# Altium schematic sheet PMU.SchDoc(PMU)
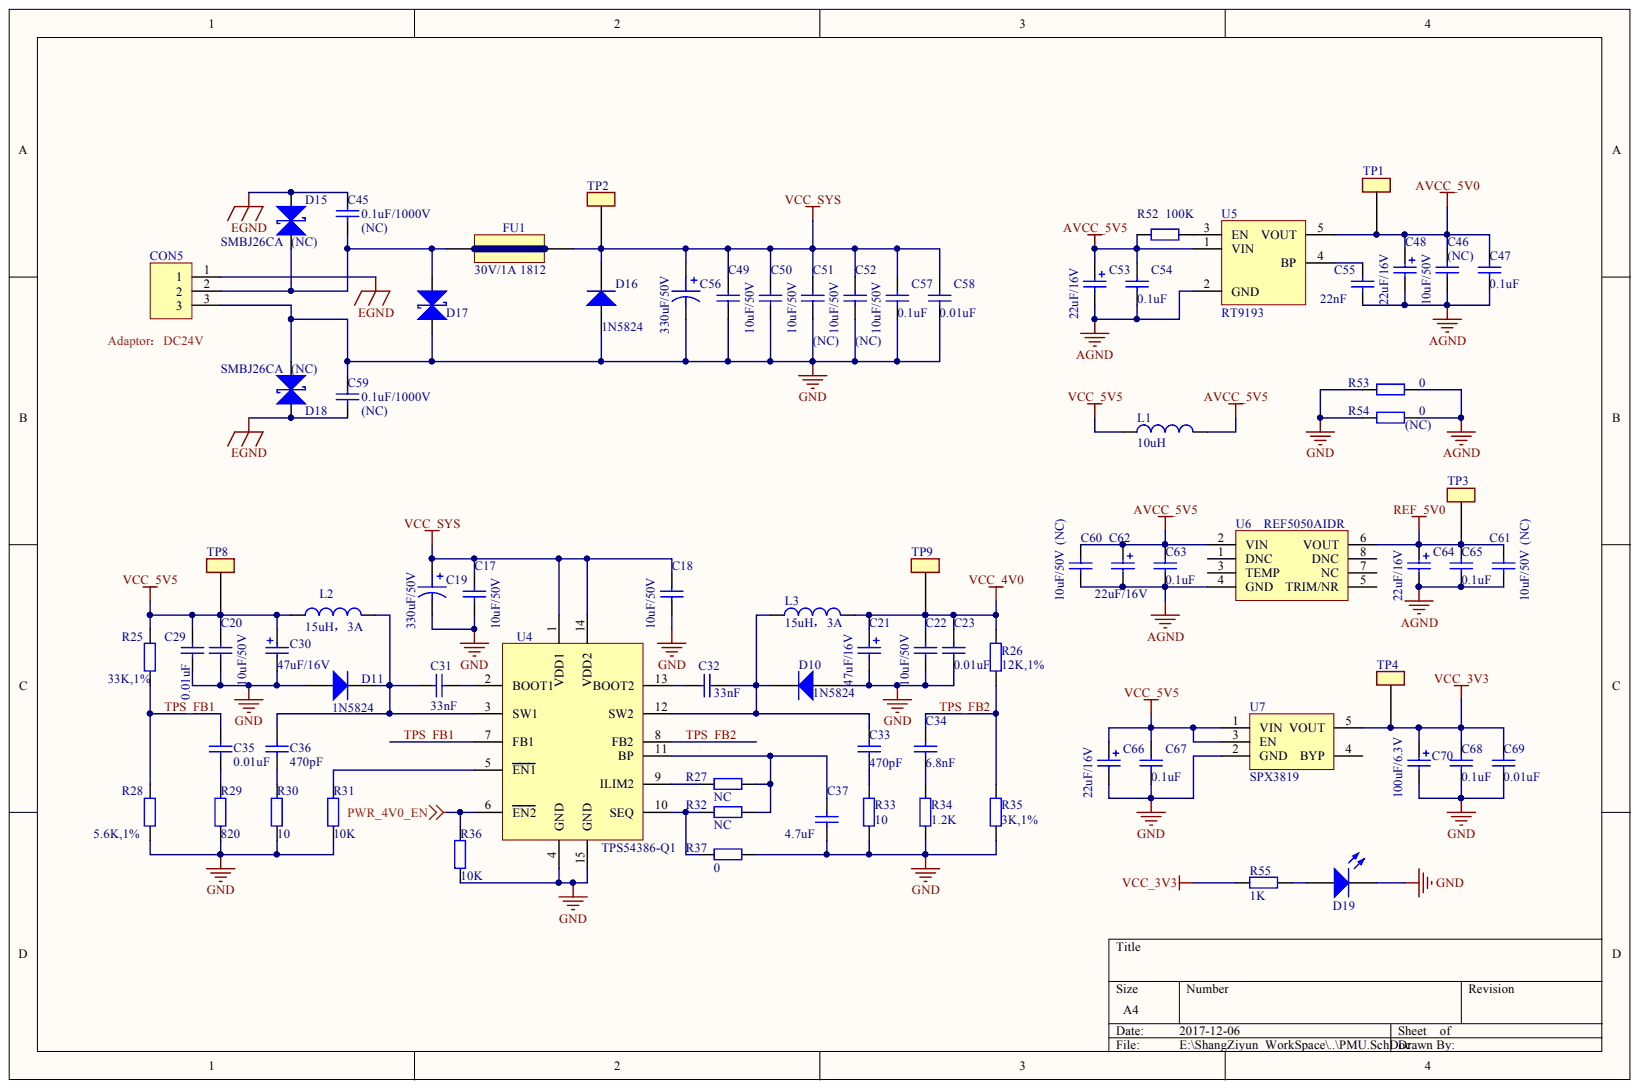
[diagram p0: PMU.SchDoc]

## Parts
C17  value 10uF/50V  [0805C]  (hidden comment: Capacitor)
C18  value 10uF/50V  [0805C]  (hidden comment: Capacitor)
C19  330uF/50V  [CAP10X10]
C20  value 10uF/50V  [0805C]  (hidden comment: Capacitor)
C21  C?  value 47uF/16V  [EIA2816P]
C22  value 10uF/50V  [0805C]  (hidden comment: Capacitor)
C23  value 0.01uF  [0603C]  (hidden comment: Capacitor)
C29  value 0.01uF  [0603C]  (hidden comment: Capacitor)
C30  C?  value 47uF/16V  [EIA2816P]
C31  value 33nF  [0603C]  (hidden comment: Capacitor)
C32  value 33nF  [0603C]  (hidden comment: Capacitor)
C33  value 470pF  [0603C]  (hidden comment: Capacitor)
C34  value 6.8nF  [0603C]  (hidden comment: Capacitor)
C35  value 0.01uF  [0603C]  (hidden comment: Capacitor)
C36  value 470pF  [0603C]  (hidden comment: Capacitor)
C37  value 4.7uF  [0603C]  (hidden comment: Capacitor)
C45  value 0.1uF/1000V  Define: (NC)  [0603C]  (hidden comment: Capacitor)
C46  value 10uF/50V  Define: (NC)  [0805C]  (hidden comment: Capacitor)
C47  value 0.1uF  [0603C]  (hidden comment: Capacitor)
C48  value 22uF/16V  [EIA1206]
C49  value 10uF/50V  [0805C]  (hidden comment: Capacitor)
C50  value 10uF/50V  [0805C]  (hidden comment: Capacitor)
C51  value 10uF/50V  Define: (NC)  [0805C]  (hidden comment: Capacitor)
C52  value 10uF/50V  Define: (NC)  [0805C]  (hidden comment: Capacitor)
C53  value 22uF/16V  [EIA1206]
C54  value 0.1uF  [0603C]  (hidden comment: Capacitor)
C55  value 22nF  [0603C]  (hidden comment: Capacitor)
C56  330uF/50V  [CAP10X10]
C57  value 0.1uF  [0603C]  (hidden comment: Capacitor)
C58  value 0.01uF  [0603C]  (hidden comment: Capacitor)
C59  value 0.1uF/1000V  Define: (NC)  [0603C]  (hidden comment: Capacitor)
C60  value 10uF/50V  Define: (NC)  [0805C]  (hidden comment: Capacitor)
C61  value 10uF/50V  Define: (NC)  [0805C]  (hidden comment: Capacitor)
C62  value 22uF/16V  [EIA1206]
C63  value 0.1uF  [0603C]  (hidden comment: Capacitor)
C64  value 22uF/16V  [EIA1206]
C65  value 0.1uF  [0603C]  (hidden comment: Capacitor)
C66  value 22uF/16V  [EIA1206]
C67  value 0.1uF  [0603C]  (hidden comment: Capacitor)
C68  value 0.1uF  [0603C]  (hidden comment: Capacitor)
C69  value 0.01uF  [0603C]  (hidden comment: Capacitor)
C70  value 100uF/6.3V  [EIA1206]
CON5  CONNECTOR_3PIN  [HT5.08-3W]  (value not drawn)
D10  value 1N5824  [DO214AC(SMA)]  (hidden comment: Diode)
D11  value 1N5824  [DO214AC(SMA)]  (hidden comment: Diode)
D15  value SMBJ26CA  Define: (NC)  [DO214AA(SMB)]  (hidden comment: D_TVS)
D16  value 1N5824  [DO214AC(SMA)]  (hidden comment: Diode)
D17  D_TVS  [DO214AB(SMC)]  (value not drawn)
D18  value SMBJ26CA  Define: (NC)  [DO214AA(SMB)]  (hidden comment: D_TVS)
D19  LED0  [LED0805]  (value not drawn)
FU1  value 30V/1A 1812  [1812]  (hidden comment: FUSE)
L1  value 10uH  [0805R]  (hidden comment: Inductor)
L2  value 15uH，3A  [0630]  (hidden comment: Inductor)
L3  value 15uH，3A  [0630]  (hidden comment: Inductor)
R25  value 33K,1%  [L0603]  (hidden comment: Resistor)
R26  value 12K,1%  [L0603]  (hidden comment: Resistor)
R27  value NC  [L0603]  (hidden comment: Resistor)
R28  value 5.6K,1%  [L0603]  (hidden comment: Resistor)
R29  value 820  [L0603]  (hidden comment: Resistor)
R30  value 10  [L0603]  (hidden comment: Resistor)
R31  value 10K  [L0603]  (hidden comment: Resistor)
R32  value NC  [L0603]  (hidden comment: Resistor)
R33  value 10  [L0603]  (hidden comment: Resistor)
R34  value 1.2K  [L0603]  (hidden comment: Resistor)
R35  value 3K,1%  [L0603]  (hidden comment: Resistor)
R36  value 10K  [L0603]  (hidden comment: Resistor)
R37  value 0  [L0603]  (hidden comment: Resistor)
R52  value 100K  [L0603]  (hidden comment: Resistor)
R53  value 0  [1206R]  (hidden comment: Resistor)
R54  value 0  Define: (NC)  [1206R]  (hidden comment: Resistor)
R55  value 1K  [L0603]  (hidden comment: Resistor)
TP1  TEST POINT_0  [TP]  (value not drawn)
TP2  TEST POINT_0  [TP]  (value not drawn)
TP3  TEST POINT_0  [TP]  (value not drawn)
TP4  TEST POINT_0  [TP]  (value not drawn)
TP8  TEST POINT_0  [TP]  (value not drawn)
TP9  TEST POINT_0  [TP]  (value not drawn)
U4  TPS54386  value TPS54386-Q1  [R-PDSO-G14]
U5  RT9193  [SOT23-5]
U6  value REF5050AIDR  [SOP8]  (hidden comment: REF50XX)
U7  SPX3819  [SOT23-5]

## Nets
AGND: C46.2 C47.2 C48.2 C53.2 C54.2 C55.2 C60.2 C61.2 C62.2 C63.2 C64.2 C65.2 R53.2 R54.2 U5.2(GND) U6.4(GND)
AVCC_5V0: C46.1 C47.1 C48.1 TP1.1 U5.5(VOUT)
AVCC_5V5: C53.1 C54.1 C60.1 C62.1 C63.1 L1.2 R52.1 U5.1(VIN) U6.2(VIN)
EGND: C45.1 C59.2 CON5.1 D15.1 D18.2
GND: C17.2 C18.2 C19.2 C20.2 C21.2 C22.2 C23.2 C29.2 C30.2 C37.2 C49.2 C50.2 C51.2 C52.2 C56.2 C57.2 C58.2 C59.1 C66.2 C67.2 C68.2 C69.2 C70.2 CON5.3 D10.1 D11.1 D16.1 D17.2 D18.1 D19.2 R28.1 R29.1 R30.1 R31.1 R33.1 R34.1 R35.1 R36.1 R37.1 R53.1 R54.1 U4.4(GND) U4.15(GND) U7.2(GND)
PWR_4V0_EN: R36.2 U4.6(EN2)
REF_5V0: C61.1 C64.1 C65.1 TP3.1 U6.6(VOUT)
VCC_3V3: C68.1 C69.1 C70.1 R55.2 TP4.1 U7.5(VOUT)
VCC_4V0: C21.1 C22.1 C23.1 L3.2 R26.2 TP9.1
VCC_5V5: C20.1 C29.1 C30.1 C66.1 C67.1 L1.1 L2.2 R25.2 TP8.1 U7.1(VIN) U7.3(EN)
VCC_SYS: C17.1 C18.1 C19.1 C49.1 C50.1 C51.1 C52.1 C56.1 C57.1 C58.1 D16.2 FU1.1 TP2.1 U4.1(VDD1) U4.14(VDD2)
TPS_FB1: C35.1 R25.1 R28.2 U4.7(FB1)
TPS_FB2: C34.1 R26.1 R35.2 U4.8(FB2)
NetC31_1: C31.1 U4.2(BOOT1)
NetC31_2: C31.2 C36.1 D11.2 L2.1 U4.3(SW1)
NetC32_1: C32.1 U4.13(BOOT2)
NetC32_2: C32.2 C33.1 D10.2 L3.1 U4.12(SW2)
NetC33_2: C33.2 R33.2
NetC34_2: C34.2 R34.2
NetC35_2: C35.2 R29.2
NetC36_2: C36.2 R30.2
NetC37_1: C37.1 R27.1 R32.1 U4.11(BP)
NetC45_2: C45.2 CON5.2 D15.2 D17.1 FU1.2
NetC55_1: C55.1 U5.4(BP)
NetD19_1: D19.1 R55.1
NetR27_2: R27.2 U4.9(ILIM2)
NetR31_2: R31.2 U4.5(EN1)
NetR32_2: R32.2 R37.2 U4.10(SEQ)
NetR52_2: R52.2 U5.3(EN)

Not connected: U6 1(DNC) 3(TEMP) 5(TRIM/NR) 7(NC) 8(DNC); U7 4(BYP)

## Library data of the parts
C17 C18 C20 C22 C23 C29 C31-C37 C45-C47 C50-C52 C54 C55 C57-C61 C63 C65 C67-C69 = Cap: Component Kind: Standard; Description: Capacitor; LatestRevisionDate: 17-Jul-2002; LatestRevisionNote: Re-released for DXP Platform.; PackageDescription: Radial Cap, Thru-Hole; 2 Leads; 0.3 in Pin Spacing; PackageReference: RAD-0.3; Published: 8-Jun-2000; Signal Integrity: Cap; Simulation: CAP; Publisher: Altium Limited
  C17 C18 C20 C22 C45 C46 C50-C52 C57-C61 C69: Library Name: Miscellaneous Devices.IntLib
  C23 C29 C31-C37 C47 C54 C55 C63 C65 C67 C68: Library Name: Lib1.SCHLIB
C19 = CAPACITOR POL_3: Value: 330uF/50V铝电解; Component Kind: Standard; Library Name: SENSOR_BOARD_Library.SchLib
C21 C30 = Cap pol: Manufacturer PN: TAJA476M010R; Component Kind: Standard; Library Name: LNG.SCHLIB
C48 C53 C62 C64 C66 C70 = Cap pol: Component Kind: Standard; Library Name: LNG.SCHLIB
C49 = Cap: Value: 10uF/50V; Component Kind: Standard; Description: Capacitor; LatestRevisionDate: 17-Jul-2002; LatestRevisionNote: Re-released for DXP Platform.; Library Name: Miscellaneous Devices.IntLib; PackageDescription: Radial Cap, Thru-Hole; 2 Leads; 0.3 in Pin Spacing; PackageReference: RAD-0.3; Published: 8-Jun-2000; Signal Integrity: Cap; Simulation: CAP; Publisher: Altium Limited
C56 = CAPACITOR POL_3: Component Kind: Standard; Library Name: SENSOR_BOARD_Library.SchLib; Value: 330uF/50V
CON5 = CONNECTOR_3PIN: Value: HT5.08-3W; Component Kind: Standard; Library Name: MyLib.SCHLIB
D17 = D_TVS: Value: SMCJ26CA; Component Kind: Standard; Library Name: MyLib.SCHLIB
D19 = LED0805: Typical INFRARED GaAs LED; Value: GREEN LED; Component Kind: Standard; LatestRevisionDate: 08-Jul-2005; LatestRevisionNote: Mech Layer 1 removed.; PackageDescription: LED; 2 Leads; PackageReference: LED-0; Published: 8-Jun-2000; Library Name: 简配版生物罐.SCHLIB; Publisher: Altium Limited
L2 L3 = Inductor: Value: 15uH，3A; Component Kind: Standard; Library Name: LNG.SCHLIB
R25-R37 R53-R55 = Res: Component Kind: Standard; Library Name: Lib1.SCHLIB
R52 = Res: Value: 100K; Component Kind: Standard; Library Name: Lib1.SCHLIB
U5 = RT9193: Value: RT9193-50PB; Component Kind: Standard; Library Name: MyLib.SCHLIB
U7 = SPX3819: Value: SPX3819-33; Component Kind: Standard; Library Name: zhileng.SCHLIB
D10 D11 D16 = Diode: Component Kind: Standard
  D10 D11: Library Name: LNG.SCHLIB
  D16: Library Name: zhileng.SchLib
D15 D18 = D_TVS: Component Kind: Standard; Library Name: MyLib.SCHLIB
FU1 = FUSE: Component Kind: Standard; Library Name: LNG.SCHLIB
L1 = Inductor: Component Kind: Standard; Library Name: LNG.SCHLIB
TP1-TP4 TP8 TP9 = TEST POINT_0: Component Kind: Standard; Library Name: MAINBOARD_Library.SchLib
U4 = TPS54386: Component Kind: Standard; Library Name: MyLib.SCHLIB
U6 = REF50XX: Component Kind: Standard; Library Name: MyLib.SCHLIB
